# Altium schematic sheet CBCM PCB Rev 1.SchDoc("CBCM PCB Rev 1")
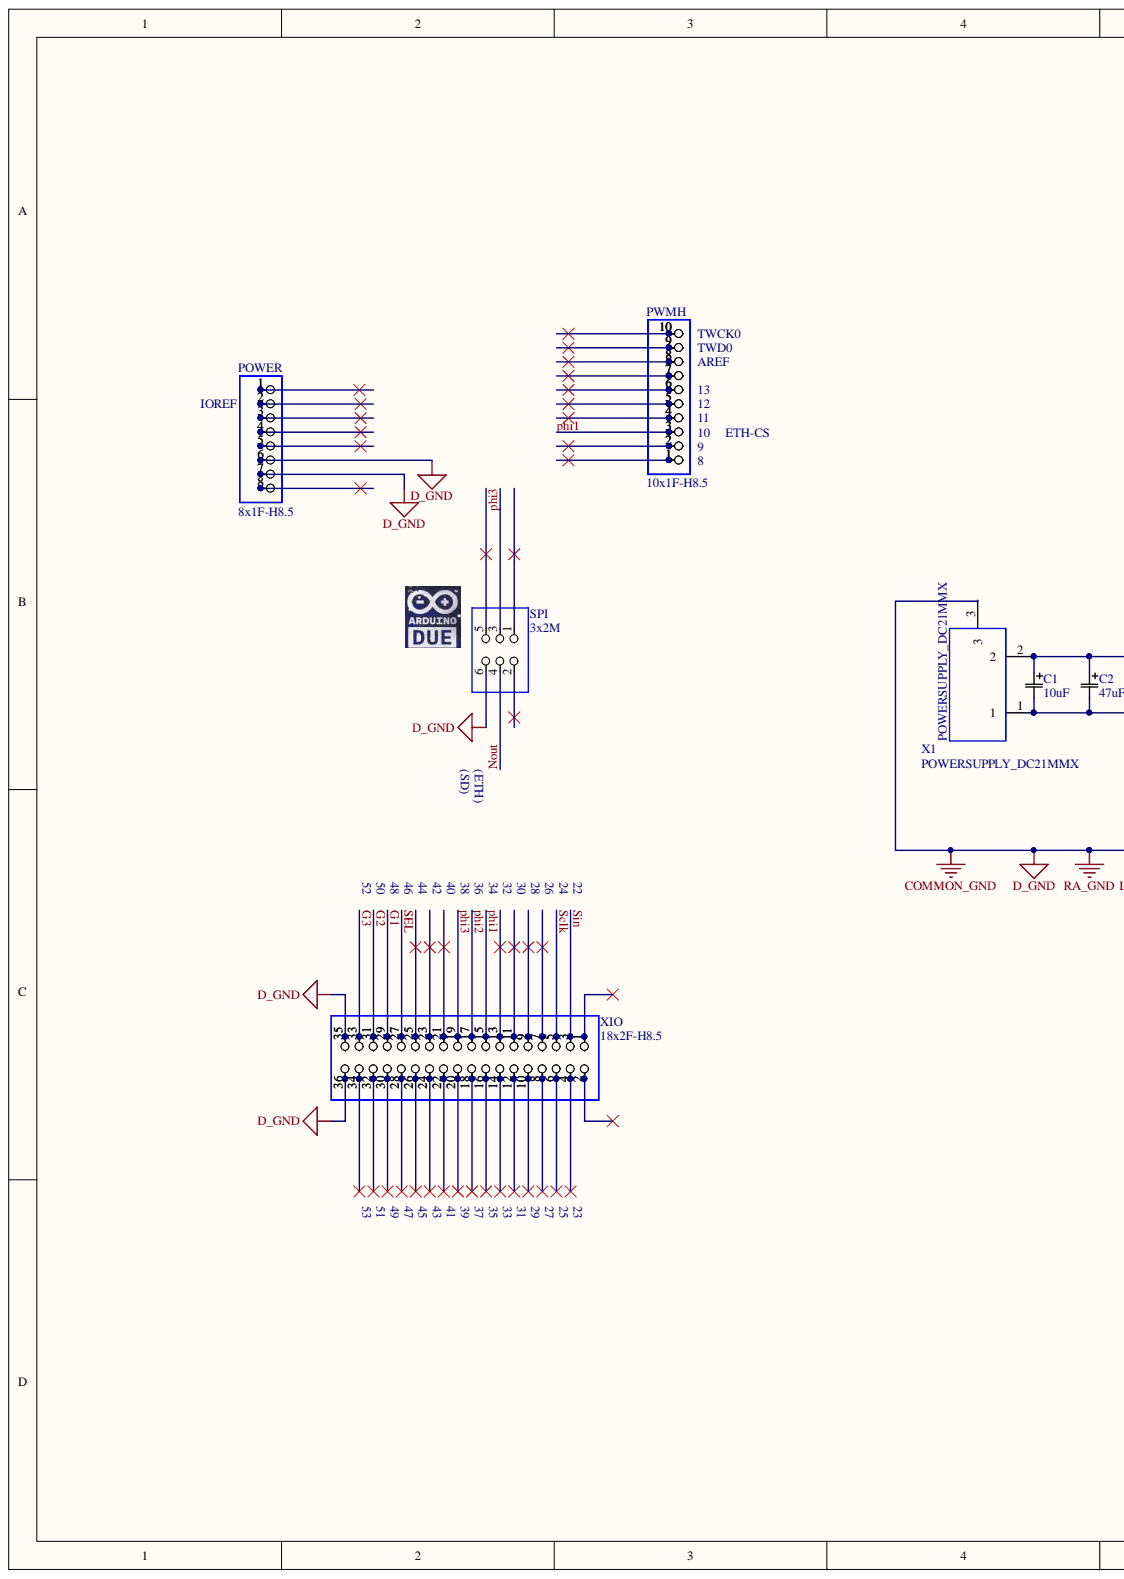
[diagram p0: CBCM PCB Rev 1.SchDoc, left]
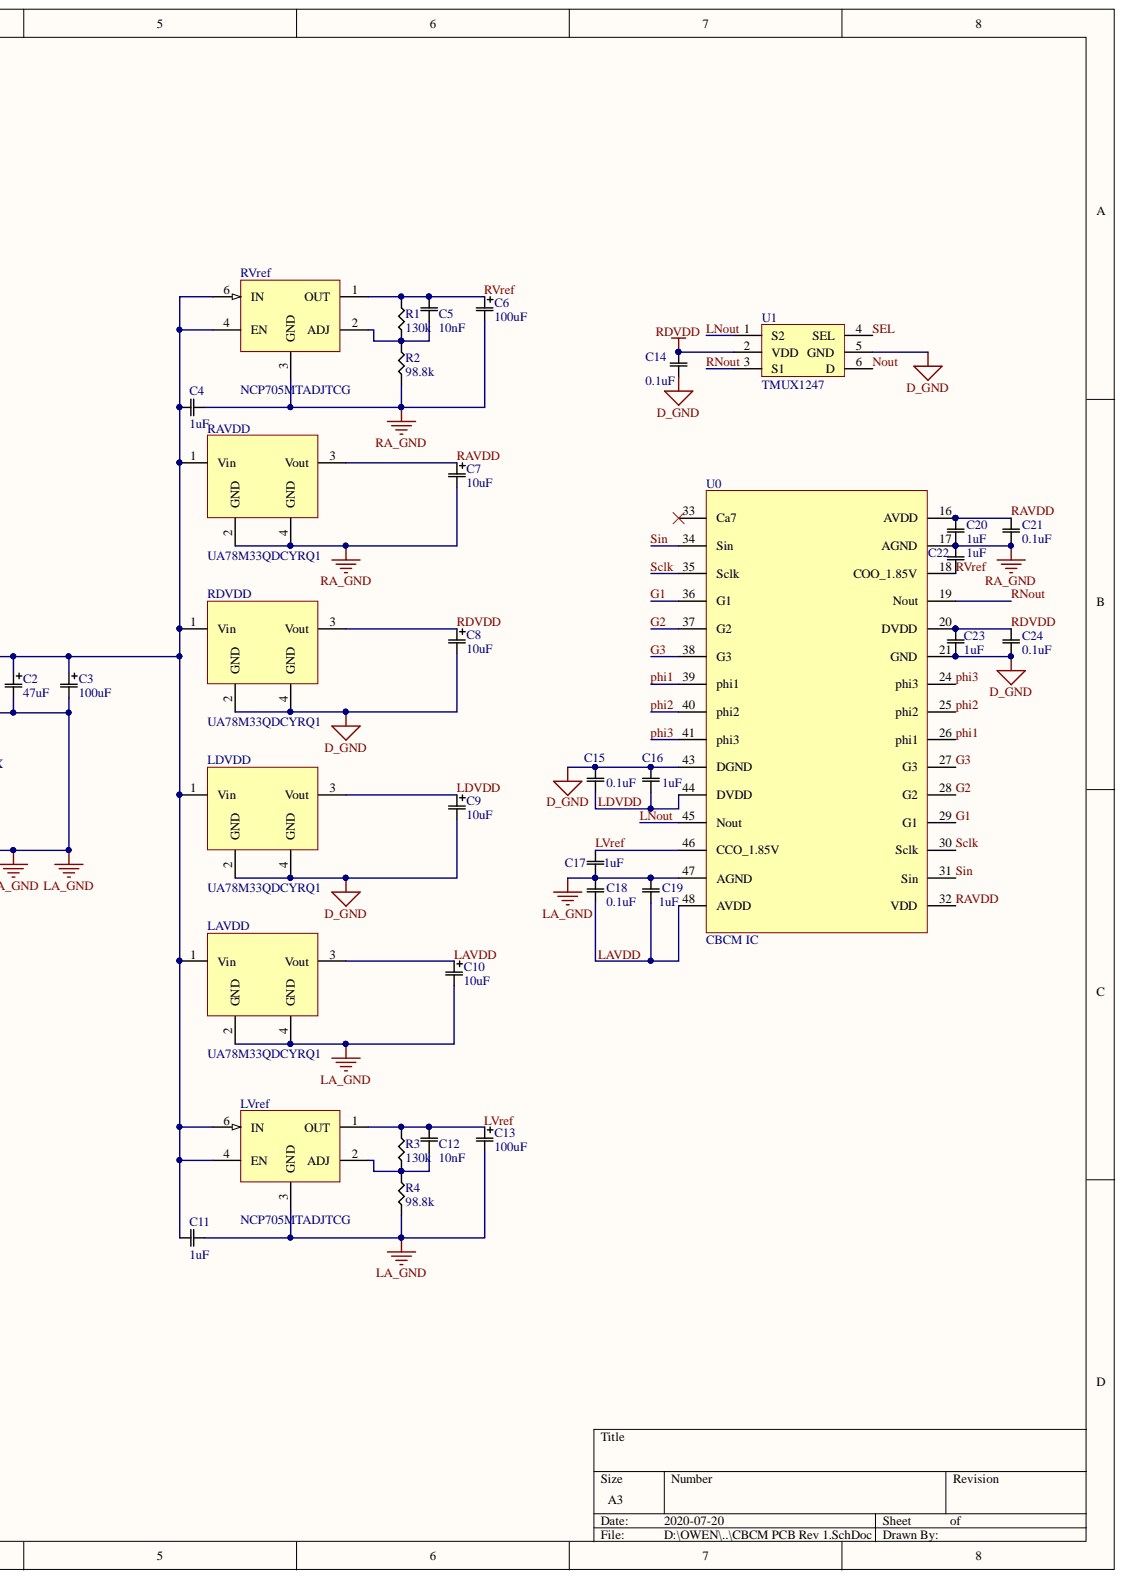
[diagram p1: CBCM PCB Rev 1.SchDoc, right]

## Parts
C1  10uF  [CAPAE4643X55M]
C2  47uF  [CAPAE4643X55M]
C3  100uF  [CAPAE7066X77M]
C4  1uF  [CAPC1608X09N]
C5  10nF  [CAPC1608X09N]
C6  100uF  [CAPAE7066X77M]
C7  10uF  [CAPAE4643X55M]
C8  10uF  [CAPAE4643X55M]
C9  10uF  [CAPAE4643X55M]
C10  10uF  [CAPAE4643X55M]
C11  1uF  [CAPC1608X09N]
C12  10nF  [CAPC1608X09N]
C13  100uF  [CAPAE7066X77M]
C14  0.1uF  [CAPC1608X09N]
C15  0.1uF  [CAPC1608X09N]
C16  1uF  [CAPC1608X09N]
C17  1uF  [CAPC1608X09N]
C18  0.1uF  [CAPC1608X09N]
C19  1uF  [CAPC1608X09N]
C20  1uF  [CAPC1608X09N]
C21  0.1uF  [CAPC1608X09N]
C22  1uF  [CAPC1608X09N]
C23  1uF  [CAPC1608X09N]
C24  0.1uF  [CAPC1608X09N]
LAVDD  UA78M33QDCYRQ1  [SOT230P700X180-4N]
LDVDD  UA78M33QDCYRQ1  [SOT230P700X180-4N]
LVref  NCP705MTADJTCG  [WDFN6]
POWER  8x1F-H8.5  [1X08]
PWMH  10x1F-H8.5  [1X10]
R1  130k  [RESC1608X09N]
R2  98.8k  [RESC1608X09N]
R3  130k  [RESC1608X09N]
R4  98.8k  [RESC1608X09N]
RAVDD  UA78M33QDCYRQ1  [SOT230P700X180-4N]
RDVDD  UA78M33QDCYRQ1  [SOT230P700X180-4N]
RVref  NCP705MTADJTCG  [WDFN6]
SPI  3x2M  [2X03]
U0  CBCM IC  [PGA85x11]
U1  TMUX1247  [TSOP65P210X110-6N]
X1  POWERSUPPLY_DC21MMX  [POWERSUPPLY_DC-21MM]
XIO  18x2F-H8.5  [2X18]

## Nets
COMMON_GND: C1.2 C2.2 C3.2 C4.2 C6.2 C7.2 C8.2 C9.2 C10.2 C11.2 C13.2 C14.1 C15.2 C16.2 C17.2 C18.2 C19.2 C20.2 C21.1 C22.2 C23.2 C24.1 LAVDD.2(GND) LAVDD.4(GND) LDVDD.2(GND) LDVDD.4(GND) LVref.3(GND) POWER.6 POWER.7 R2.1 R4.1 RAVDD.2(GND) RAVDD.4(GND) RDVDD.2(GND) RDVDD.4(GND) RVref.3(GND) SPI.6 U0.17(AGND) U0.21(GND) U0.43(DGND) U0.47(AGND) U1.5(GND) X1.1 X1.3 XIO.35 XIO.36
G1: U0.29(G1) U0.36(G1) XIO.29
G2: U0.28(G2) U0.37(G2) XIO.31
G3: U0.27(G3) U0.38(G3) XIO.33
LAVDD: C10.1 C18.1 C19.1 LAVDD.3(Vout) U0.48(AVDD)
LDVDD: C9.1 C15.1 C16.1 LDVDD.3(Vout) U0.44(DVDD)
LNout: U0.45(Nout) U1.1(S2)
LVref: C12.2 C13.1 C17.2 LVref.1(OUT) R3.2 U0.46(CCO_1.85V)
Nout: SPI.4 U1.6(D)
phi1: PWMH.3 U0.26(phi1) U0.39(phi1) XIO.15
phi2: U0.25(phi2) U0.40(phi2) XIO.17
phi3: SPI.3 U0.24(phi3) U0.41(phi3) XIO.19
RAVDD: C7.1 C20.2 C21.2 RAVDD.3(Vout) U0.16(AVDD) U0.32(VDD)
RDVDD: C8.1 C14.2 C23.2 C24.2 RDVDD.3(Vout) U0.20(DVDD) U1.2(VDD)
RNout: U0.19(Nout) U1.3(S1)
RVref: C5.2 C6.1 C22.1 R1.2 RVref.1(OUT) U0.18(COO_1.85V)
Sclk: U0.30(Sclk) U0.35(Sclk) XIO.5
SEL: U1.4(SEL) XIO.27
Sin: U0.31(Sin) U0.34(Sin) XIO.3
NetC1_1: C1.1 C2.1 C3.1 C4.1 C11.1 LAVDD.1(Vin) LDVDD.1(Vin) LVref.4(EN) LVref.6(IN) RAVDD.1(Vin) RDVDD.1(Vin) RVref.4(EN) RVref.6(IN) X1.2
NetC5_1: C5.1 R1.1 R2.2 RVref.2(ADJ)
NetC12_1: C12.1 LVref.2(ADJ) R3.1 R4.2
NetPOWER_1: POWER.1
NetPOWER_2: POWER.2
NetPOWER_3: POWER.3
NetPOWER_4: POWER.4
NetPOWER_5: POWER.5
NetPOWER_8: POWER.8
NetPWMH_1: PWMH.1
NetPWMH_2: PWMH.2
NetPWMH_4: PWMH.4
NetPWMH_5: PWMH.5
NetPWMH_6: PWMH.6
NetPWMH_7: PWMH.7
NetPWMH_8: PWMH.8
NetPWMH_9: PWMH.9
NetPWMH_10: PWMH.10
NetXIO_1: XIO.1
NetXIO_2: XIO.2
NetXIO_4: XIO.4
NetXIO_6: XIO.6
NetXIO_7: XIO.7
NetXIO_8: XIO.8
NetXIO_9: XIO.9
NetXIO_10: XIO.10
NetXIO_11: XIO.11
NetXIO_12: XIO.12
NetXIO_13: XIO.13
NetXIO_14: XIO.14
NetXIO_16: XIO.16
NetXIO_18: XIO.18
NetXIO_20: XIO.20
NetXIO_21: XIO.21
NetXIO_22: XIO.22
NetXIO_23: XIO.23
NetXIO_24: XIO.24
NetXIO_25: XIO.25
NetXIO_26: XIO.26
NetXIO_28: XIO.28
NetXIO_30: XIO.30
NetXIO_32: XIO.32
NetXIO_34: XIO.34

Not connected: SPI 1 2 5; U0 33(Ca7)

## Library data of the parts
C1 C2 C7-C10 = Electrolytic_CAP(4.0x5.5): Component Kind: Standard; Library Name: CBCM IC.SchLib
C3 C6 C13 = Electrolytic_CAP(6.3x7.7): Component Kind: Standard; Library Name: CBCM IC.SchLib
C4 C5 C11 C12 C14-C24 = CAP0603: Component Kind: Standard; Library Name: CBCM IC.SchLib
LVref RVref = NCP705MTADJTCG: 500 mA, Ultra-Low  Quiescent Current, IQ 13 A,  Ultra-Low Noise, LDO  Voltage Regulator; Manufacturer: ON Semiconductor; Manufacturer Part Number: NCP705MTADJTCG; Library Name: NCP705MTADJTCG.SchLib; Digi-Key link: https://www.digikey.ca/product-detail/en/on-semiconductor/NCP705MTADJTCG/NCP705MTADJTCGOSCT-ND/8538627; Component Kind: Standard
POWER = PINHD-1X8: Library Name: SmartPrj; Component Kind: Standard
PWMH = PINHD-1X10: Library Name: SmartPrj; Component Kind: Standard
R1-R4 = RES0603: Component Kind: Standard; Library Name: CBCM IC.SchLib
SPI = PINHD-2X3: Library Name: SmartPrj; Component Kind: Standard
XIO = PINHD-2X18: Library Name: SmartPrj; Component Kind: Standard
LAVDD LDVDD RAVDD RDVDD = UA78M33QDCYRQ1: Component Kind: Standard; Library Name: CBCM IC.SchLib
U0 = CBCM IC: Library Name: CBCM IC.SchLib; Component Kind: Standard
U1 = TMUX1247: Component Kind: Standard; Library Name: CBCM IC.SchLib
X1 = POWERSUPPLY_DC21MMX: Component Kind: Standard; Library Name: Arduino_Due.SCHLIB
